# Altium schematic sheet FPGA_PWR.SchDoc
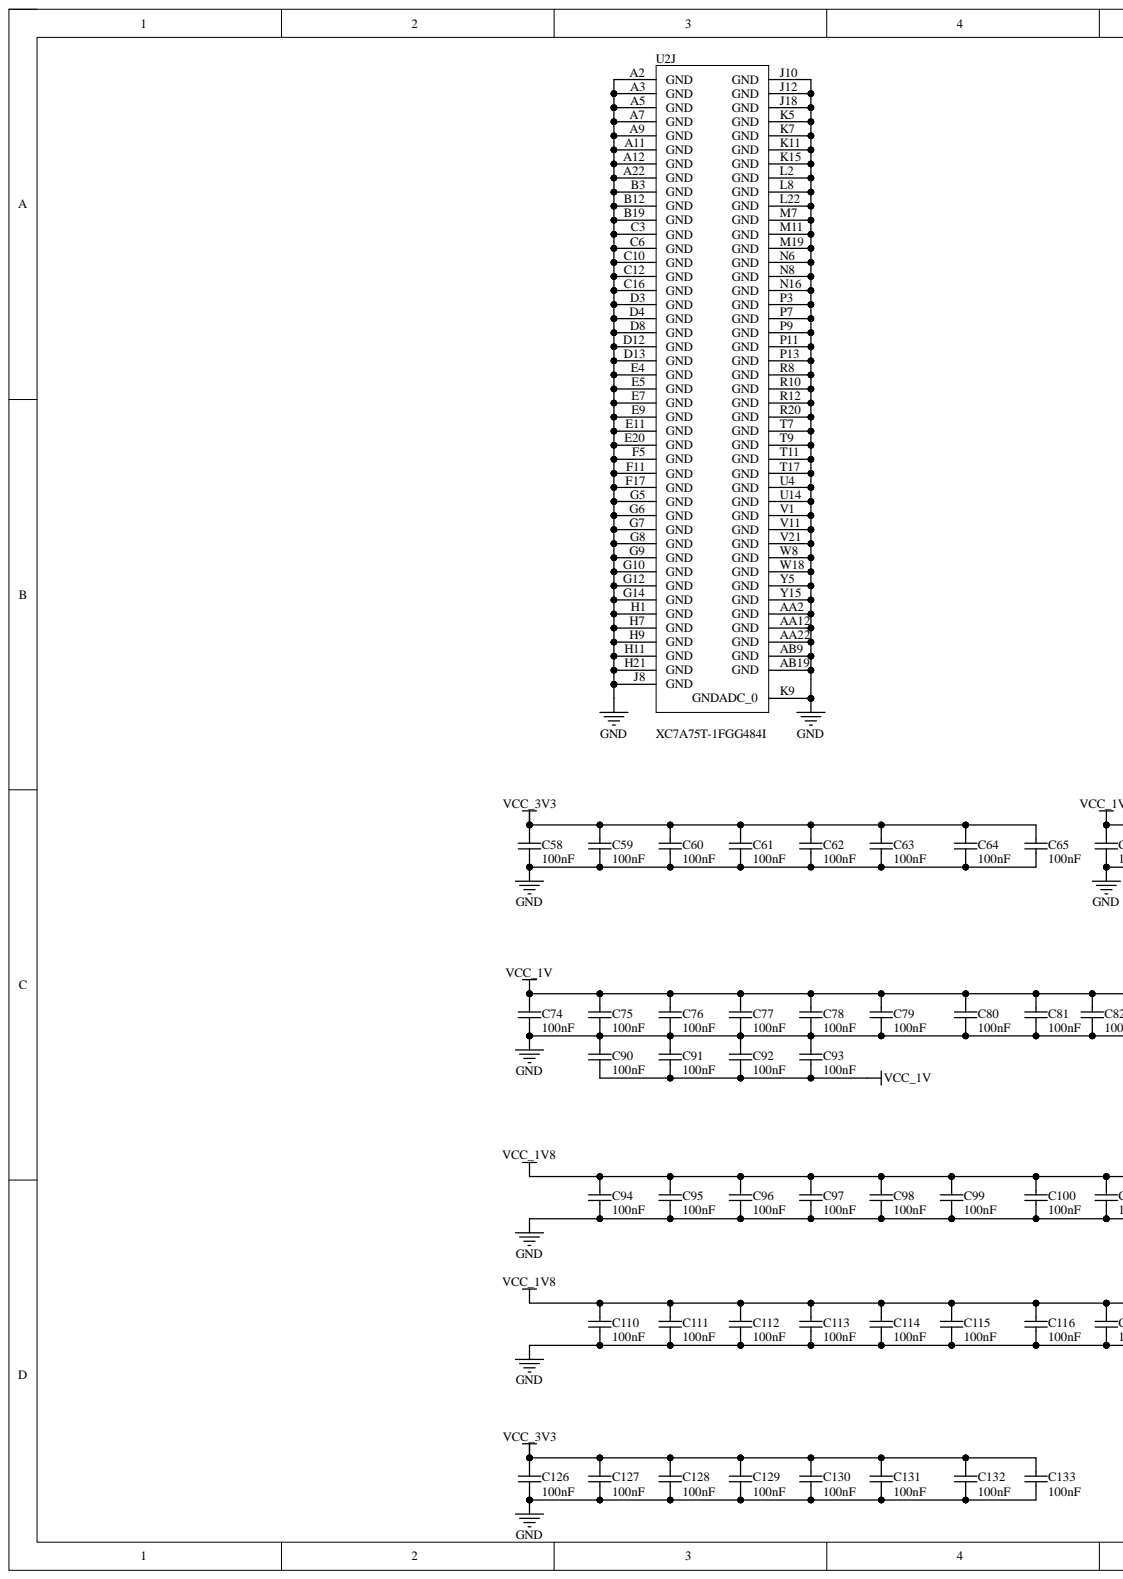
[diagram p0: FPGA_PWR.SchDoc, left]
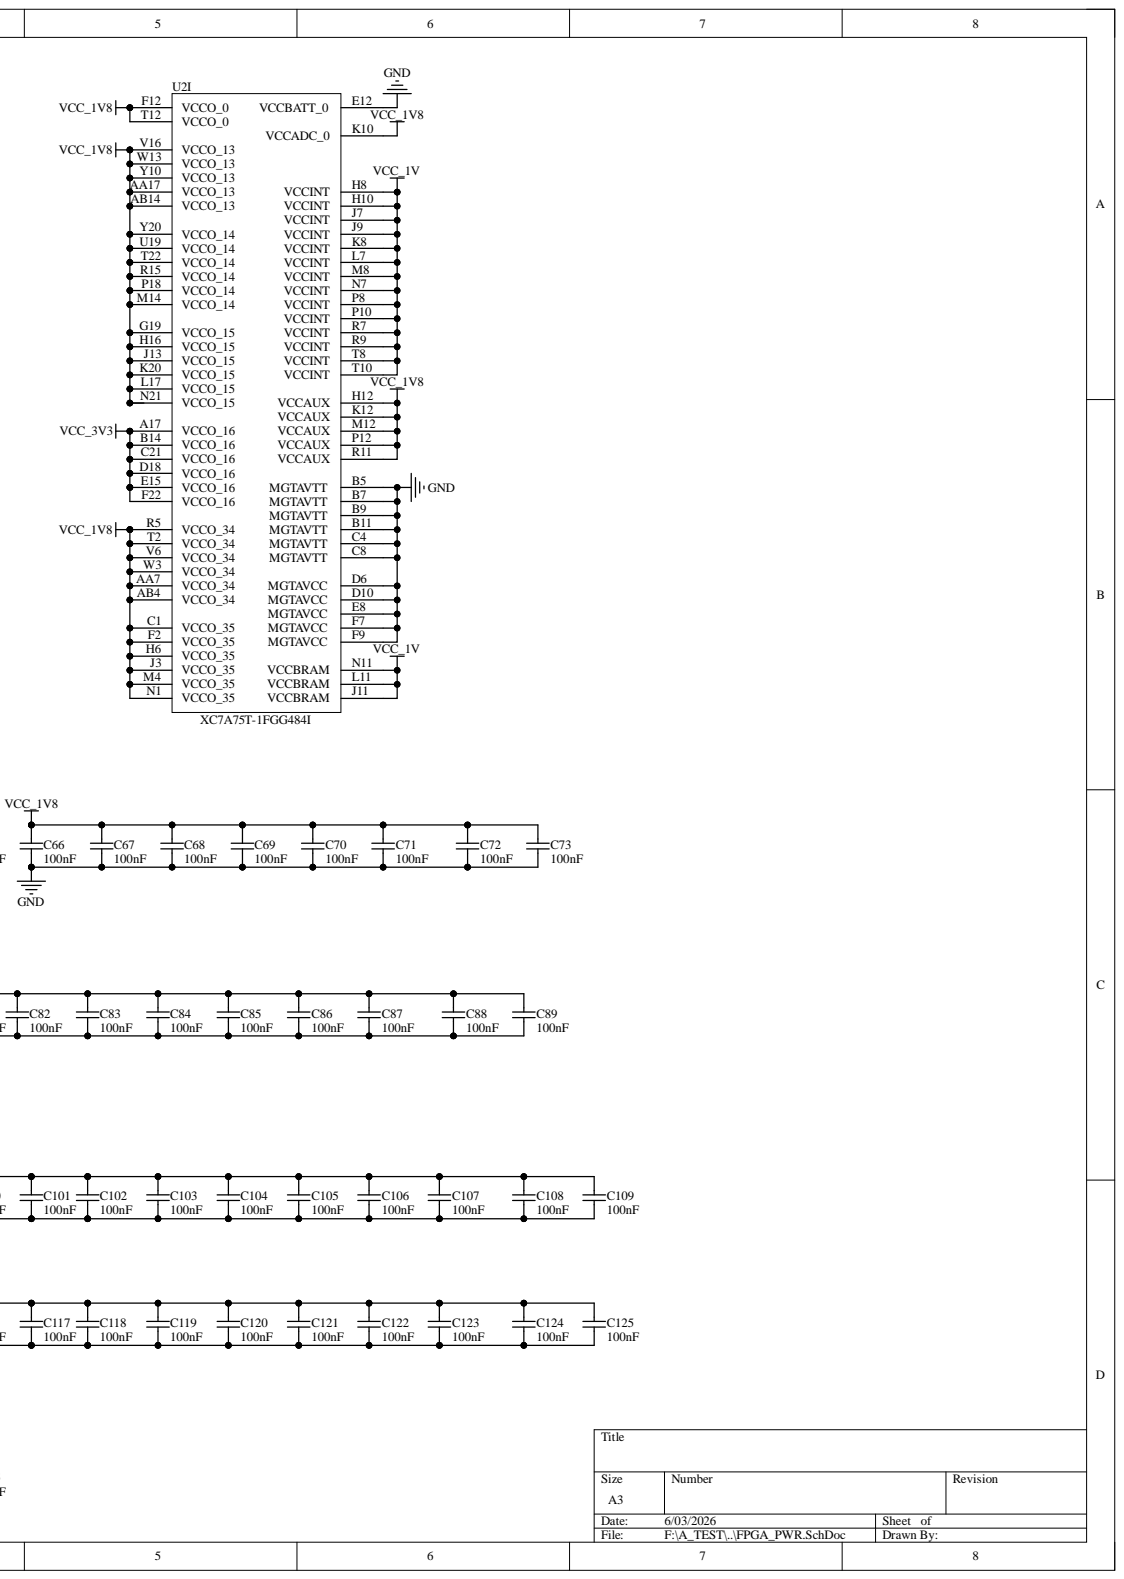
[diagram p1: FPGA_PWR.SchDoc, right]

## Parts
C58  100nF  [C 0402_L]
C59  100nF  [C 0402_L]
C60  100nF  [C 0402_L]
C61  100nF  [C 0402_L]
C62  100nF  [C 0402_L]
C63  100nF  [C 0402_L]
C64  100nF  [C 0402_L]
C65  100nF  [C 0402_L]
C66  100nF  [C 0402_L]
C67  100nF  [C 0402_L]
C68  100nF  [C 0402_L]
C69  100nF  [C 0402_L]
C70  100nF  [C 0402_L]
C71  100nF  [C 0402_L]
C72  100nF  [C 0402_L]
C73  100nF  [C 0402_L]
C74  100nF  [C 0402_L]
C75  100nF  [C 0402_L]
C76  100nF  [C 0402_L]
C77  100nF  [C 0402_L]
C78  100nF  [C 0402_L]
C79  100nF  [C 0402_L]
C80  100nF  [C 0402_L]
C81  100nF  [C 0402_L]
C82  100nF  [C 0402_L]
C83  100nF  [C 0402_L]
C84  100nF  [C 0402_L]
C85  100nF  [C 0402_L]
C86  100nF  [C 0402_L]
C87  100nF  [C 0402_L]
C88  100nF  [C 0402_L]
C89  100nF  [C 0402_L]
C90  100nF  [C 0402_L]
C91  100nF  [C 0402_L]
C92  100nF  [C 0402_L]
C93  100nF  [C 0402_L]
C94  100nF  [C 0402_L]
C95  100nF  [C 0402_L]
C96  100nF  [C 0402_L]
C97  100nF  [C 0402_L]
C98  100nF  [C 0402_L]
C99  100nF  [C 0402_L]
C100  100nF  [C 0402_L]
C101  100nF  [C 0402_L]
C102  100nF  [C 0402_L]
C103  100nF  [C 0402_L]
C104  100nF  [C 0402_L]
C105  100nF  [C 0402_L]
C106  100nF  [C 0402_L]
C107  100nF  [C 0402_L]
C108  100nF  [C 0402_L]
C109  100nF  [C 0402_L]
C110  100nF  [C 0402_L]
C111  100nF  [C 0402_L]
C112  100nF  [C 0402_L]
C113  100nF  [C 0402_L]
C114  100nF  [C 0402_L]
C115  100nF  [C 0402_L]
C116  100nF  [C 0402_L]
C117  100nF  [C 0402_L]
C118  100nF  [C 0402_L]
C119  100nF  [C 0402_L]
C120  100nF  [C 0402_L]
C121  100nF  [C 0402_L]
C122  100nF  [C 0402_L]
C123  100nF  [C 0402_L]
C124  100nF  [C 0402_L]
C125  100nF  [C 0402_L]
C126  100nF  [C 0402_L]
C127  100nF  [C 0402_L]
C128  100nF  [C 0402_L]
C129  100nF  [C 0402_L]
C130  100nF  [C 0402_L]
C131  100nF  [C 0402_L]
C132  100nF  [C 0402_L]
C133  100nF  [C 0402_L]
U2  XC7A75T-1FGG484I  [FGG484]  (parts I,J)

## Nets
GND: C58.1 C59.1 C60.1 C61.1 C62.1 C63.1 C64.1 C65.1 C66.1 C67.1 C68.1 C69.1 C70.1 C71.1 C72.1 C73.1 C74.1 C75.1 C76.1 C77.1 C78.1 C79.1 C80.1 C81.1 C82.1 C83.1 C84.1 C85.1 C86.1 C87.1 C88.1 C89.1 C90.2 C91.2 C92.2 C93.2 C94.1 C95.1 C96.1 C97.1 C98.1 C99.1 C100.1 C101.1 C102.1 C103.1 C104.1 C105.1 C106.1 C107.1 C108.1 C109.1 C110.1 C111.1 C112.1 C113.1 C114.1 C115.1 C116.1 C117.1 C118.1 C119.1 C120.1 C121.1 C122.1 C123.1 C124.1 C125.1 C126.1 C127.1 C128.1 C129.1 C130.1 C131.1 C132.1 C133.1 U2.A2(GND) U2.A3(GND) U2.A5(GND) U2.A7(GND) U2.A9(GND) U2.A11(GND) U2.A12(GND) U2.A22(GND) U2.AA2(GND) U2.AA12(GND) U2.AA22(GND) U2.AB9(GND) U2.AB19(GND) U2.B3(GND) U2.B5(MGTAVTT) U2.B7(MGTAVTT) U2.B9(MGTAVTT) U2.B11(MGTAVTT) U2.B12(GND) U2.B19(GND) U2.C3(GND) U2.C4(MGTAVTT) U2.C6(GND) U2.C8(MGTAVTT) U2.C10(GND) U2.C12(GND) U2.C16(GND) U2.D3(GND) U2.D4(GND) U2.D6(MGTAVCC) U2.D8(GND) U2.D10(MGTAVCC) U2.D12(GND) U2.D13(GND) U2.E4(GND) U2.E5(GND) U2.E7(GND) U2.E8(MGTAVCC) U2.E9(GND) U2.E11(GND) U2.E12(VCCBATT_0) U2.E20(GND) U2.F5(GND) U2.F7(MGTAVCC) U2.F9(MGTAVCC) U2.F11(GND) U2.F17(GND) U2.G5(GND) U2.G6(GND) U2.G7(GND) U2.G8(GND) U2.G9(GND) U2.G10(GND) U2.G12(GND) U2.G14(GND) U2.H1(GND) U2.H7(GND) U2.H9(GND) U2.H11(GND) U2.H21(GND) U2.J8(GND) U2.J10(GND) U2.J12(GND) U2.J18(GND) U2.K5(GND) U2.K7(GND) U2.K9(GNDADC_0) U2.K11(GND) U2.K15(GND) U2.L2(GND) U2.L8(GND) U2.L22(GND) U2.M7(GND) U2.M11(GND) U2.M19(GND) U2.N6(GND) U2.N8(GND) U2.N16(GND) U2.P3(GND) U2.P7(GND) U2.P9(GND) U2.P11(GND) U2.P13(GND) U2.R8(GND) U2.R10(GND) U2.R12(GND) U2.R20(GND) U2.T7(GND) U2.T9(GND) U2.T11(GND) U2.T17(GND) U2.U4(GND) U2.U14(GND) U2.V1(GND) U2.V11(GND) U2.V21(GND) U2.W8(GND) U2.W18(GND) U2.Y5(GND) U2.Y15(GND)
VCC_1V: C74.2 C75.2 C76.2 C77.2 C78.2 C79.2 C80.2 C81.2 C82.2 C83.2 C84.2 C85.2 C86.2 C87.2 C88.2 C89.2 C90.1 C91.1 C92.1 C93.1 U2.H8(VCCINT) U2.H10(VCCINT) U2.J7(VCCINT) U2.J9(VCCINT) U2.J11(VCCBRAM) U2.K8(VCCINT) U2.L7(VCCINT) U2.L11(VCCBRAM) U2.M8(VCCINT) U2.N7(VCCINT) U2.N11(VCCBRAM) U2.P8(VCCINT) U2.P10(VCCINT) U2.R7(VCCINT) U2.R9(VCCINT) U2.T8(VCCINT) U2.T10(VCCINT)
VCC_1V8: C66.2 C67.2 C68.2 C69.2 C70.2 C71.2 C72.2 C73.2 C94.2 C95.2 C96.2 C97.2 C98.2 C99.2 C100.2 C101.2 C102.2 C103.2 C104.2 C105.2 C106.2 C107.2 C108.2 C109.2 C110.2 C111.2 C112.2 C113.2 C114.2 C115.2 C116.2 C117.2 C118.2 C119.2 C120.2 C121.2 C122.2 C123.2 C124.2 C125.2 U2.AA7(VCCO_34) U2.AA17(VCCO_13) U2.AB4(VCCO_34) U2.AB14(VCCO_13) U2.C1(VCCO_35) U2.F2(VCCO_35) U2.F12(VCCO_0) U2.G19(VCCO_15) U2.H6(VCCO_35) U2.H12(VCCAUX) U2.H16(VCCO_15) U2.J3(VCCO_35) U2.J13(VCCO_15) U2.K10(VCCADC_0) U2.K12(VCCAUX) U2.K20(VCCO_15) U2.L17(VCCO_15) U2.M4(VCCO_35) U2.M12(VCCAUX) U2.M14(VCCO_14) U2.N1(VCCO_35) U2.N21(VCCO_15) U2.P12(VCCAUX) U2.P18(VCCO_14) U2.R5(VCCO_34) U2.R11(VCCAUX) U2.R15(VCCO_14) U2.T2(VCCO_34) U2.T12(VCCO_0) U2.T22(VCCO_14) U2.U19(VCCO_14) U2.V6(VCCO_34) U2.V16(VCCO_13) U2.W3(VCCO_34) U2.W13(VCCO_13) U2.Y10(VCCO_13) U2.Y20(VCCO_14)
VCC_3V3: C58.2 C59.2 C60.2 C61.2 C62.2 C63.2 C64.2 C65.2 C126.2 C127.2 C128.2 C129.2 C130.2 C131.2 C132.2 C133.2 U2.A17(VCCO_16) U2.B14(VCCO_16) U2.C21(VCCO_16) U2.D18(VCCO_16) U2.E15(VCCO_16) U2.F22(VCCO_16)

## Library data of the parts
C58-C133 = Cap: 贴片电容; Component Kind: Standard; Library Name: A_Schlib.SchLib; value: 100nF
U2 = XC7A100T-1FGG484I: Artix-7 FPGA, 285 User I/Os, 4 GTP, 484-Ball BGA, Speed Grade 1, Industrial Grade, Pb-Free; Manufacturer: Xilinx; Block RAM Blocks (18Kb): 270; Block RAM Blocks (36Kb): 135; Block RAM Blocks (Max Kb): 4860; CLBs (Slices): 15850; Clock Mgmt Tiles (CMTs): 6; DSP48E1 Slices: 240; Logic Cells: 101440; Max User I/O: 300; Speed Grade: -1; CLBs (Max Distributed RAM Kb): 1188; Code_JEDEC: MS-034-AAJ-1; Component Kind: Standard; ComponentLink1Description: Datasheet; ComponentLink1URL: http://www.xilinx.com/support/documentation/data_sheets/ds180_7Series_Overview.pdf; ComponentLink2Description: Package Specification; ComponentLink2URL: http://www.xilinx.com/support/documentation/user_guides/ug475_7Series_Pkg_Pinout.pdf; ComponentLink3Description: DC and Switching Characteristics; ComponentLink3URL: http://www.xilinx.com/support/documentation/data_sheets/ds181_Artix_7_Data_Sheet.pdf; ComponentLink4Description: Package File; ComponentLink4URL: http://www.xilinx.com/support/packagefiles/a7packages/xc7a100tfgg484pkg.txt; ComponentLink5Description: Packaging and Pinout; ComponentLink5URL: http://www.xilinx.com/support/documentation/user_guides/ug475_7Series_Pkg_Pinout.pdf; DatasheetVersion: v1.12; Generic Part Number: XC7A100T; Library Name: Xilinx Artix-7.IntLib; Mounting Technology: Surface Mount; PackageDescription: Wire-Bond Fine-Pitch BGA, Body 23 x 23 mm, 1.0 mm Pitch; PackageReference: FGG484; PackageVersion: Rev 1.8; PartNumber: XC7A100T-1FGG484I; RoHS: Pb-Free
